# Altium schematic sheet SilentBotStep.SchDoc(SilentBotStep)
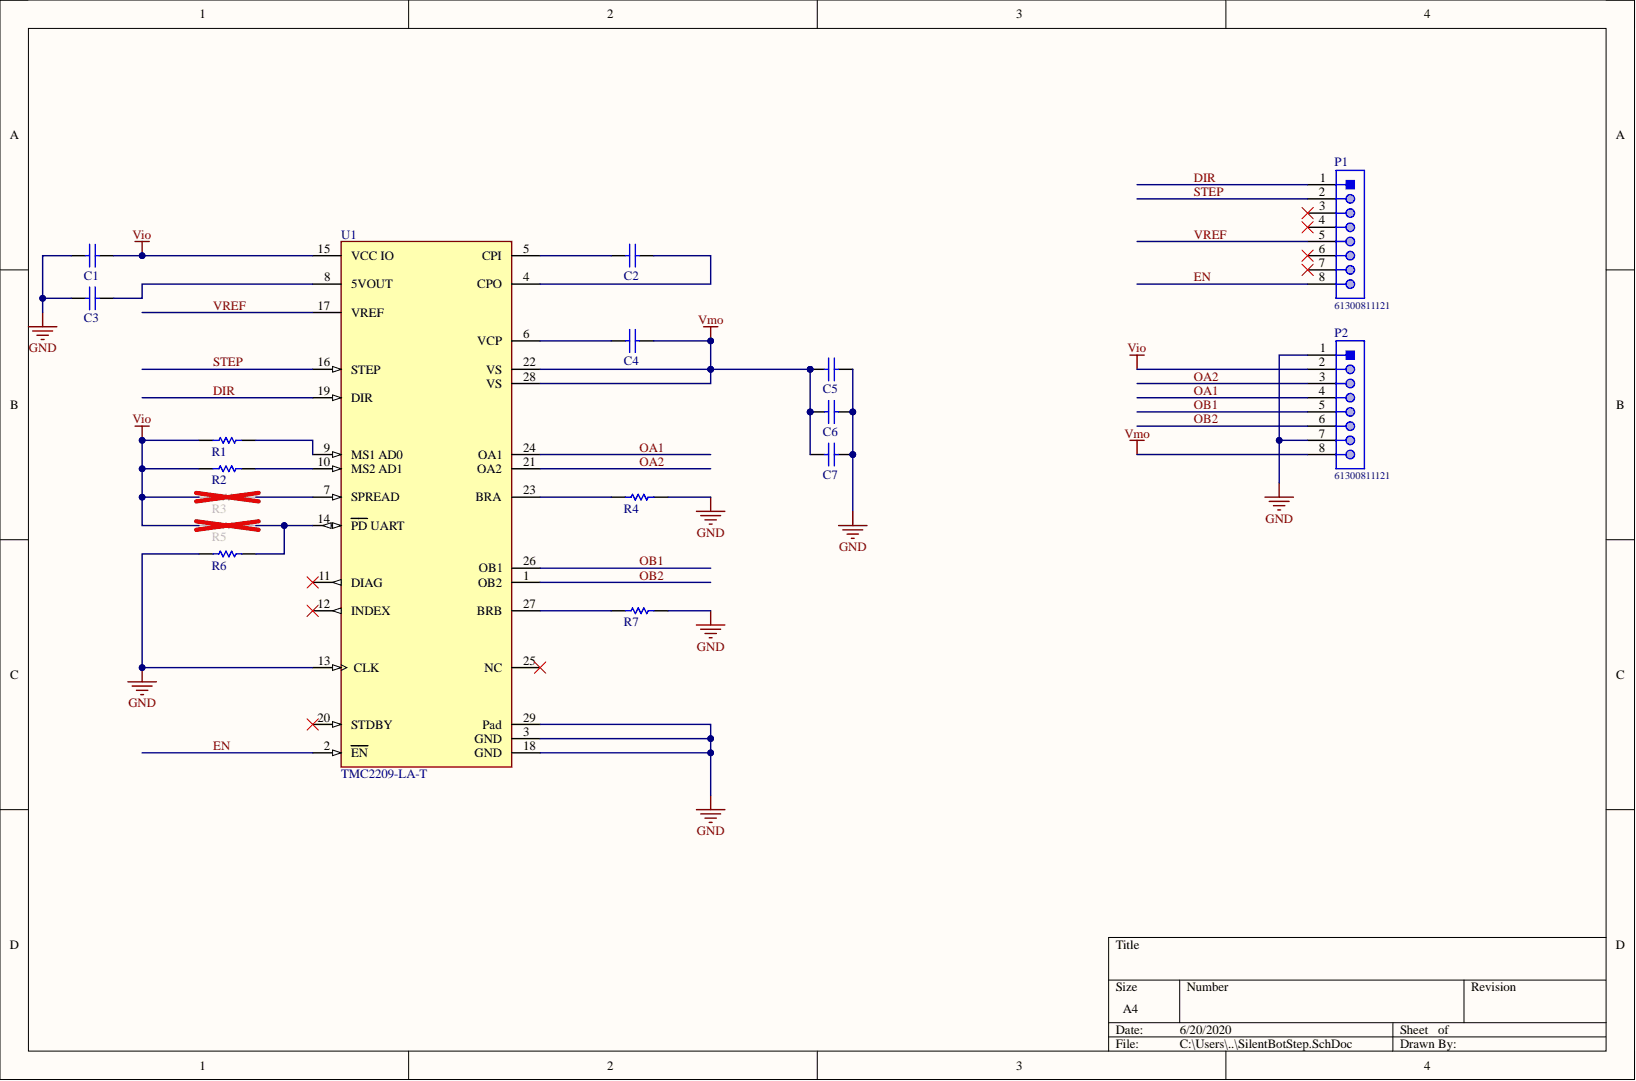
[diagram p0: SilentBotStep.SchDoc]

## Parts
C1  GRM155R71H104KE14D  [CAPC1005X55X25LL05T10]  (value not drawn)
C2  CC0402KRX7R9BB223  [CAPC1005X55X25LL05T10]  (value not drawn)
C3  GRM155R60J475ME47D  [CAPC1005X55X25LL05T10]  (value not drawn)
C4  GRM155R71H104KE14D  [CAPC1005X55X25LL05T10]  (value not drawn)
C5  GRM155R71H104KE14D  [CAPC1005X55X25LL05T10]  (value not drawn)
C6  GRM155R71H104KE14D  [CAPC1005X55X25LL05T10]  (value not drawn)
C7  C3216X7R1V106M160AC  [CAPC3216X180X20LL20]  (value not drawn)
P1  61300811121  [61300811121]
P2  61300811121  [61300811121]
R1  RC0402JR-070RL  [RESC1005X40X25LL05T10]  (value not drawn)
R2  RC0402JR-070RL  [RESC1005X40X25LL05T10]  (value not drawn)
R3  RC0402JR-070RL  [RESC1005X40X25LL05T10]  (value not drawn)
R4  RL1220S-R18-F  [RESC2013X50X40LL20T20]  (value not drawn)
R5  RC0402JR-070RL  [RESC1005X40X25LL05T10]  (value not drawn)
R6  RC0402JR-070RL  [RESC1005X40X25LL05T10]  (value not drawn)
R7  RL1220S-R18-F  [RESC2013X50X40LL20T20]  (value not drawn)
U1  TMC2209-LA-T  [Trinamic_0_IPC_C]

## Nets
GND: C1.1 C3.1 C5.2 C6.2 C7.2 P2.1 P2.7 R4.2 R6.1 R7.2 U1.3(GND) U1.13(CLK) U1.18(GND) U1.29(Pad)
Vio: C1.2 P2.2 R1.1 R2.1 R3.1 R5.1 U1.15(VCC_IO)
Vmo: C4.2 C5.1 C6.1 C7.1 P2.8 U1.22(VS) U1.28(VS)
DIR: P1.1 U1.19(DIR)
EN: P1.8 U1.2(EN)
OA1: P2.4 U1.24(OA1)
OA2: P2.3 U1.21(OA2)
OB1: P2.5 U1.26(OB1)
OB2: P2.6 U1.1(OB2)
STEP: P1.2 U1.16(STEP)
VREF: P1.5 U1.17(VREF)
NetC2_1: C2.1 U1.5(CPI)
NetC2_2: C2.2 U1.4(CPO)
NetC3_2: C3.2 U1.8(5VOUT)
NetC4_1: C4.1 U1.6(VCP)
NetR1_2: R1.2 U1.9(MS1_AD0)
NetR2_2: R2.2 U1.10(MS2_AD1)
NetR3_2: R3.2 U1.7(SPREAD)
NetR4_1: R4.1 U1.23(BRA)
NetR5_2: R5.2 R6.2 U1.14(PD_UART)
NetR7_1: R7.1 U1.27(BRB)

Not connected: P1 3 4 6 7; U1 11(DIAG) 12(INDEX) 20(STDBY) 25(NC)

## Library data of the parts
C1 C4-C6 = CMP-001-00001-1: MURATA - GRM155R71H104KE14D - CAP, MLCC, X7R, 0.1UF, 50V, 0402; Capacitance: 100nF; Dielectric Material: Ceramic; Dissipation Factor: 0.025%; Tolerance: 10%; Case Code (Imperial): 0402; Case Code (Metric): 1005; Case/Package: 0402; Component Kind: Standard; Composition: Ceramic; Depth: 0.5mm; Dielectric: X7R; Height: 0.5mm; Lead Free: Lead Free; Length: 1mm; Material: Ceramic; Max Operating Temperature: 125°C; Min Operating Temperature: -55°C; Mount: Surface Mount; Package Quantity: 10000; Packaging: Tape and Reel; REACH SVHC: No SVHC; Termination: SMD/SMT; Thickness: 0.022inch; Voltage: 50V; Voltage Rating: 50V; Voltage Rating (DC): 50V; Weight: 0.000212oz; Width: 0.5mm; Library Name: LTY LLC
C2 = CMP-001-00002-2: Multilayer Ceramic Capacitors MLCC - SMD/SMT 22K pF 10% 50 Volts; Capacitance: 22nF; Dielectric: X7R; Voltage Rating: 50V; Case Code (Imperial): 0402; Case Code (Metric): 1005; Case/Package: 0402; Component Kind: Standard; Composition: Ceramic; Depth: 0.5mm; Halogen Free: Halogen Free; Lead Free: Lead Free; Length: 1mm; Max Operating Temperature: 125°C; Min Operating Temperature: -55°C; Mount: Surface Mount; Package Quantity: 10000; Packaging: Tape and Reel; Termination: SMD/SMT; Thickness: 0.022inch; Tolerance: 10%; Voltage Rating (DC): 50V; Weight: 2.3E-05oz; Width: 0.02inch; Library Name: LTY LLC
C3 = CMP-001-00003-1: CAP CER 4.7UF 6.3V X5R 0402; Tolerance: 20%; Capacitance: 4.7uF; Case Code (Imperial): 0402; Case Code (Metric): 1005; Case/Package: 0402; Component Kind: Standard; Contact Plating: Tin; Depth: 0.5mm; Dielectric: X5R; Height: 0.5mm; Lead Free: Lead Free; Length: 1mm; Max Operating Temperature: 85°C; Min Operating Temperature: -55°C; Mount: Surface Mount; Package Quantity: 10000; Packaging: Tape and Reel; REACH SVHC: No SVHC; Termination: SMD/SMT; Thickness: 0.022inch; Voltage: 6.3V; Voltage Rating: 6.3V; Voltage Rating (DC): 6.3V; Weight: 0.000212oz; Width: 0.02inch; Library Name: LTY LLC
C7 = CMP-001-00000-1: CERAMIC CAPACITOR, 10UF, 35V, X7R, 1206, FULL REEL; Product Range:C Series; Capacitance:10 F; Capacitance Tolerance: 20%; Dielectric Characteristic:X7R; Voltage Rating:35V; Ceramic Capacitor Case:1206 [3216 Metric]; MSL:- ;RoHS Compliant: Yes; Capacitance: 10uF; Case Code (Imperial): 1206; Case Code (Metric): 3216; Case/Package: 1206; Component Kind: Standard; Composition: Ceramic; Depth: 1.6mm; Dielectric: X7R; Dielectric Material: Ceramic; Height: 1.6mm; Lead Free: Lead Free; Length: 3.2mm; Max Operating Temperature: 125°C; Min Operating Temperature: -55°C; Mount: Surface Mount; Package Quantity: 2000; Packaging: Tape and Reel; REACH SVHC: No SVHC; Termination: SMD/SMT; Thickness: 0.071inch; Tolerance: 20%; Voltage Rating: 35V; Voltage Rating (DC): 35V; Weight: 0.000571oz; Width: 0.063inch; Library Name: LTY LLC
P1 P2 = CMP-002-00000-1: Board-To-Board Connector, Vertical, Wr-Phd Series, Through Hole, Header, 8, 2.54 Mm; Connector Type: Male Pin; Gender: Male; Number of Contacts: 8; Pitch: 0.1inch; Color: Black; Component Kind: Standard; Contact Material: Copper; Contact Mating Length: 0.236inch; Contact Plating: Gold; Mount: Through Hole; Number of Positions: 8; Number of Rows: 1; Packaging: Bulk; REACH SVHC: Unknown; Termination: Solder; Library Name: LTY LLC
R1-R3 R5 R6 = CMP-009-00001-1: YAGEO - RC0402JR-070RL. - RES, THICK FILM, 0R, 0.0625W, 0402; Max Power Dissipation: 63mW; Power Rating: 62.5mW; Resistance: 0mR; Temperature Coefficient: 200ppm/°C; Tolerance: 5%; Voltage Rating: 50V; Case Code (Imperial): 0402; Case Code (Metric): 1005; Case/Package: 0402; Component Kind: Standard; Composition: Thick Film; Contact Plating: Tin,Matte; Depth: 0.5mm; Features: Moisture Resistant; Height: 0.016inch; Lead Free: Lead Free; Length: 1mm; Max Operating Temperature: 155°C; Military Standard: Not; Min Operating Temperature: -55°C; Number of Terminations: 2; Package Quantity: 1; Packaging: Tape and Reel; Radiation Hardening: No; REACH SVHC: Unknown; Termination: SMD/SMT; Width: 0.02inch; Library Name: LTY LLC
R4 R7 = CMP-009-00000-1: RES SMD 180 MOHM 1% 1/3W 0805; Max Power Dissipation: 333mW; Power Rating: 250mW; Resistance: 180mR; Temperature Coefficient: 200ppm/°C; Voltage Rating (DC): 100V; Case Code (Imperial): 0805; Case Code (Metric): 2012; Case/Package: 0805; Component Kind: Standard; Composition: Thin Film; Height: 0.02inch; Lead Free: Lead Free; Length: 0.079inch; Max Operating Temperature: 125°C; Min Operating Temperature: -55°C; Number of Pins: 2; Number of Terminations: 2; Package Quantity: 1; Packaging: Tape and Reel; REACH SVHC: No SVHC; Termination: SMD/SMT; Tolerance: 1%; Weight: 0.000194oz; Width: 0.049inch; Library Name: LTY LLC
U1 = CMP-012-0001-3: Motor Driver, Stepper, QFN-28; Component Kind: Standard; Library Name: LTY LLC
